# Altium schematic sheet MCU Power Clock Reset.SchDoc
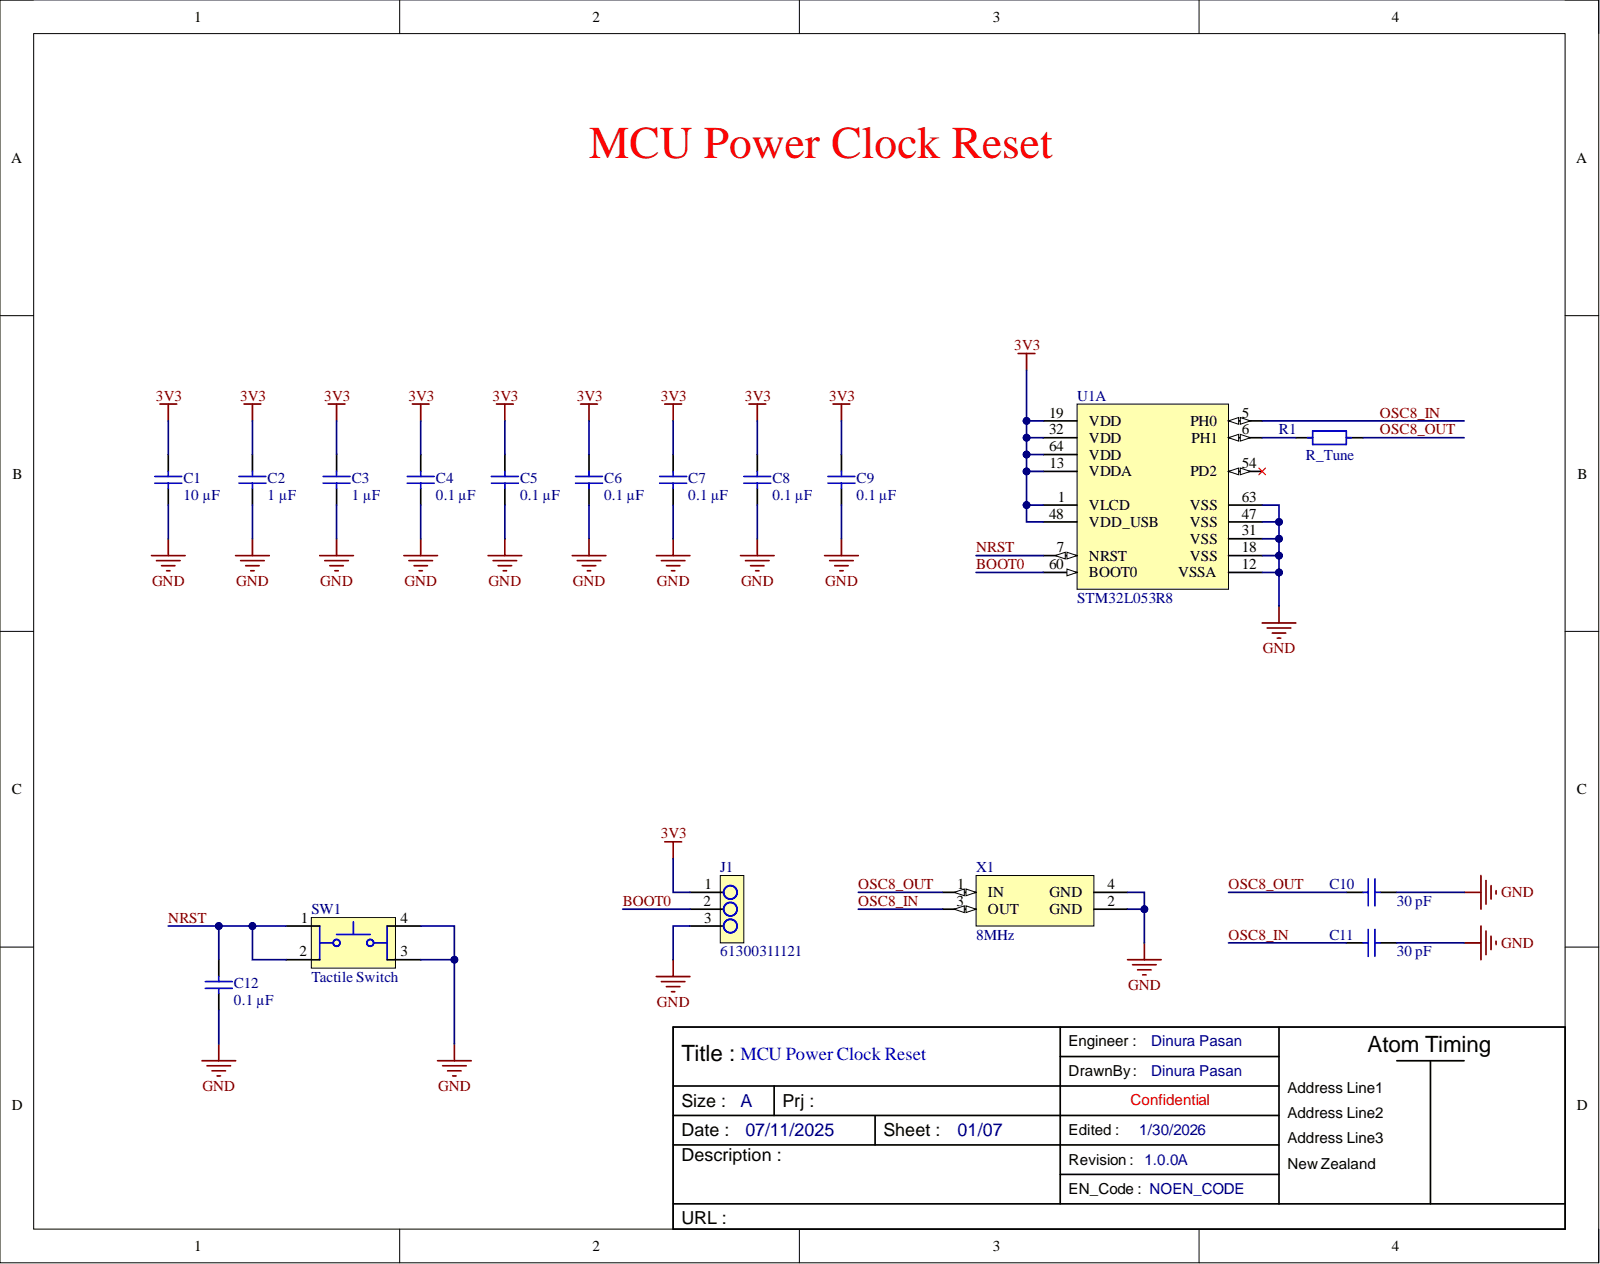
[diagram p0: MCU Power Clock Reset.SchDoc]

## Parts
C1  10 µF  [TDK_CGA1206N]
C2  1 µF  [TDK_CGA0805N]
C3  1 µF  [TDK_CGA0805N]
C4  0.1 µF  [TDK_CGA0805N]
C5  0.1 µF  [TDK_CGA0805N]
C6  0.1 µF  [TDK_CGA0805N]
C7  0.1 µF  [TDK_CGA0805N]
C8  0.1 µF  [TDK_CGA0805N]
C9  0.1 µF  [TDK_CGA0805N]
C10  30 pF  [YAGEO_CCNP00402N]
C11  30 pF  [YAGEO_CCNP00402N]
C12  0.1 µF  [TDK_CGA0805N]
J1  61300311121  [61300311121]
R1  R_Tune  [Panasonic_ERA6AN]  (no BOM)
SW1  Tactile Switch  [TL3313AF160QG]
U1  STM32L053R8  [ST-LQFP64]  (part A)
X1  8MHz  [ECS-80-10-33-CKM-TR3]

## Nets
3V3: C1.2 C2.2 C3.2 C4.2 C5.2 C6.2 C7.2 C8.2 C9.2 J1.1 U1.1(VLCD) U1.13(VDDA) U1.19(VDD) U1.32(VDD) U1.48(VDD_USB) U1.64(VDD)
GND: C1.1 C2.1 C3.1 C4.1 C5.1 C6.1 C7.1 C8.1 C9.1 C10.2 C11.2 C12.2 J1.3 SW1.3 SW1.4 U1.12(VSSA) U1.18(VSS) U1.31(VSS) U1.47(VSS) U1.63(VSS) X1.2(GND) X1.4(GND)
BOOT0: J1.2 U1.60(BOOT0)
NRST: C12.1 SW1.1 SW1.2 U1.7(NRST)
OSC8_IN: C11.1 U1.5(PH0) X1.3(OUT)
OSC8_OUT: C10.1 R1.1 X1.1(IN)
NetR1_2: R1.2 U1.6(PH1)

Not connected: U1 54(PD2)

## Library data of the parts
C1 = CGA5L1X7R1C106M160AC: 10 µF ±20% 16V X7R -55°C ~ 125°C 1206 (3216 Metric); Manufacturer: TDK Corporation; Database Table Name: Sheet1$; Eco Plan: RoHS & Green; Initial Voltage: 0V; TEMP: 25; Value: 10u; Capacitance: 10 µF; Category: Ceramic Capacitor (MLCC); Component Kind: Standard; Datasheet: https://product.tdk.com/system/files/dam/doc/product/capacitor/ceramic/mlcc/catalog/mlcc_automotive_general_en.pdf; Library Name: MLCC.DbLib; Manufacturer Part Number: CGA5L1X7R1C106M160AC; Operating Temperature: -55°C ~ 125°C; Package / Case: 1206 (3216 Metric); Ratings: AEC-Q200; Series: CGA; Simulation: C_Capacitor_Default; Size / Dimension: 0.126" L x 0.063" W (3.20mm x 1.60mm); Supplier 1: Digi-Key; Supplier Part Number 1: 445-12903-2-ND; Temperature Coefficient: X7R; Thickness (Max): 0.075" (1.90mm); Tolerance: ±20%; Voltage - Rated: 16V
C2 C3 = CGA4J3X7R1H105K125AB: 1 µF ±10% 50V X7R -55°C ~ 125°C 0805 (2012 Metric); Manufacturer: TDK Corporation; Database Table Name: Sheet1$; Eco Plan: RoHS & Green; Initial Voltage: 0V; TEMP: 25; Value: 1u; Capacitance: 1 µF; Category: Ceramic Capacitor (MLCC); Component Kind: Standard; Datasheet: https://product.tdk.com/system/files/dam/doc/product/capacitor/ceramic/mlcc/catalog/mlcc_automotive_general_en.pdf; Library Name: MLCC.DbLib; Manufacturer Part Number: CGA4J3X7R1H105K125AB; Operating Temperature: -55°C ~ 125°C; Package / Case: 0805 (2012 Metric); Ratings: AEC-Q200; Series: CGA; Simulation: C_Capacitor_Default; Size / Dimension: 0.079" L x 0.049" W (2.00mm x 1.25mm); Supplier 1: Digi-Key; Supplier Part Number 1: 445-6961-2-ND; Temperature Coefficient: X7R; Thickness (Max): 0.059" (1.50mm); Tolerance: ±10%; Voltage - Rated: 50V
C4-C9 C12 = CGA4J2X7R2A104K125AE: 0.1 µF ±10% 100V X7R -55°C ~ 125°C 0805 (2012 Metric); Manufacturer: TDK Corporation; Database Table Name: Sheet1$; Eco Plan: RoHS & Green; Initial Voltage: 0V; TEMP: 25; Value: 0.1u; Capacitance: 0.1 µF; Category: Ceramic Capacitor (MLCC); Component Kind: Standard; Datasheet: https://product.tdk.com/system/files/dam/doc/product/capacitor/ceramic/mlcc/catalog/mlcc_automotive_general_en.pdf; Library Name: MLCC.DbLib; Manufacturer Part Number: CGA4J2X7R2A104K125AE; Operating Temperature: -55°C ~ 125°C; Package / Case: 0805 (2012 Metric); Ratings: AEC-Q200; Series: CGA; Simulation: C_Capacitor_Default; Size / Dimension: 0.079" L x 0.049" W (2.00mm x 1.25mm); Supplier 1: Digi-Key; Supplier Part Number 1: 445-15961-2-ND; Temperature Coefficient: X7R; Thickness (Max): 0.059" (1.50mm); Tolerance: ±10%; Voltage - Rated: 100V
C10 C11 = CC0402GRNPO9BN300: 30 pF ±2% 50V C0G, NP0 -55°C ~ 125°C 0402 (1005 Metric); Manufacturer: YAGEO; Database Table Name: Sheet1$; Eco Plan: RoHS & Green; Initial Voltage: 0V; TEMP: 25; Value: 30p; Capacitance: 30 pF; Category: Ceramic Capacitor (MLCC); Component Kind: Standard; Datasheet: https://www.yageo.com/upload/media/product/products/datasheet/mlcc/UPY-GP_NP0_16V-to-50V_18.pdf; Library Name: MLCC.DbLib; Manufacturer Part Number: CC0402GRNPO9BN300; Operating Temperature: -55°C ~ 125°C; Package / Case: 0402 (1005 Metric); Ratings: -; Series: CC NP0; Simulation: C_Capacitor_Default; Size / Dimension: 0.039" L x 0.020" W (1.00mm x 0.50mm); Supplier 1: Digi-Key; Supplier Part Number 1: 311-3740-2-ND; Temperature Coefficient: C0G, NP0; Thickness (Max): 0.022" (0.55mm); Tolerance: ±2%; Voltage - Rated: 50V
J1 = 61300311121: Connector Header Through Hole 3 position 0.100" (2.54mm); Manufacturer 1: Wurth Elektronik; Category 1: Headers and Wire Housings; Connector Type: Male Pin; Contact Resistance: 20mΩ; Current Rating: 3A; Description 1: THT Vertical Pin Header WR-PHD, Pitch 2.54 mm, Single Row, 3 pins; Gender: Male; Number of Contacts: 3; Operating Supply Voltage: 250V; Packaging 1: Bulk; Color: Black; Component Kind: Standard; ComponentLink1Description: https://datasheet.octopart.com:443/61300311121-Wurth-Elektronik-datasheet-168684096.pdf?src-supplier=Upverter; ComponentLink1URL: https://datasheet.octopart.com:443/61300311121-Wurth-Elektronik-datasheet-168684096.pdf?src-supplier=Upverter; ComponentLink2Description: https://datasheet.octopart.com:443/61300311121-W%C3%BCrth-Elektronik-datasheet-44382959.pdf?src-supplier=Future+Electronics; ComponentLink2URL: https://datasheet.octopart.com:443/61300311121-W%C3%BCrth-Elektronik-datasheet-44382959.pdf?src-supplier=Future+Electronics; ComponentLink3Description: https://datasheet.octopart.com:443/61300311121-W%C3%BCrth-Elektronik-datasheet-149524047.pdf?src-supplier=Farnell; ComponentLink3URL: https://datasheet.octopart.com:443/61300311121-W%C3%BCrth-Elektronik-datasheet-149524047.pdf?src-supplier=Farnell; ComponentLink4Description: https://datasheet.octopart.com:443/61300311121-W%C3%BCrth-Elektronik-datasheet-21154836.pdf?src-supplier=element14+APAC; ComponentLink4URL: https://datasheet.octopart.com:443/61300311121-W%C3%BCrth-Elektronik-datasheet-21154836.pdf?src-supplier=element14+APAC; Contact Material: Gold; Contact Mating Length: 5.9944mm; Contact Plating: Gold; Height: 8.94mm; Lead Free 1: Lead Free; Lead Pitch: 2.54mm; Library Name: Components.IntLib; Manufacturer Part Number 1: 61300311121; Max Operating Temperature 1: 105°C; Min Operating Temperature 1: -40°C; Mount 1: Through Hole; Number of Pins 1: 3; Number of Positions: 3; Number of Rows: 1; Orientation: Vertical; Pitch: 2.54mm; RoHS 1: Compliant; Supplier 1: DigiKey; Supplier 2: Mouser; Supplier 3: Newark; Supplier 4: Farnell; Supplier Part Number 1: 732-5316-ND; Supplier Part Number 2: 710-61300311121; Supplier Part Number 3: 61300311121; Supplier Part Number 4: 2356154; Termination: Solder; Voltage Rating: 250V
R1 = ERA-6ARW102V: 1 kΩ ±0.05% 0.125W, 1/8W Thin Film 0805 (2012 Metric) 100V ±10ppm/°C -55°C ~ 155°C; Manufacturer: Panasonic Electronic Components; Database Table Name: Sheet1$; Eco Plan: RoHS & Green; Maximum Overload Voltage: 200V; Rated Voltage: √(PxR); Resistance: 1 kΩ; TC: 10; TC1: 0; TEMP: 25; Value: 1kΩ; Category: SMD Thin Film Resistor; Composition: Thin Film; Datasheet: https://industrial.panasonic.com/cdbs/www-data/pdf/RDM0000/AOA0000C307.pdf; Height - Seated (Max): 0.024" (0.60mm); Library Name: SMD_Thin_Film_Resistors.DbLib; Manufacturer Part Number: ERA-6ARW102V; Maximum Working Voltage: 100V; Operating Temperature: -55°C ~ 155°C; Package / Case: 0805 (2012 Metric); Power (Watts): 0.125W, 1/8W; Ratings: AEC-Q200; Series: ERA; Simulation: R_Resistor_Default; Size / Dimension: 0.079" L x 0.049" W (2.00mm x 1.25mm); Supplier 1: Digi-Key; Supplier Part Number 1: P1.0KBSTR-ND; TC2: 0; Temperature Coefficient: ±10ppm/°C; Tolerance: ±0.05%
SW1 = TL3313AF160QG: Tactile Switch SPST-NO Top Actuated Surface Mount; Manufacturer: E-Switch; Actuator Length: 0.3mm; Actuator Type: Standard; Contact Resistance: 50mR; Current Rating: 50mA; Illumination Type: Non-Illuminated; Max Voltage Rating (DC): 12V; Mechanical Life: 100000Cycles; Operating Force: 160gf; Packaging 1: Tape and Reel; Switch Function: Off-Mom; Switch Travel: 0.25mm; Throw Configuration: SPST; Category: Tactile Switches; Component Kind: Standard; ComponentLink1Description: Datasheet; ComponentLink1URL: https://sten-eswitch-13110800-production.s3.amazonaws.com/system/asset/product_line/data_sheet/161/TL3313.pdf; Library Name: Common_library.IntLib; Manufacturer Part Number: TL3313AF160QG; Max Operating Temperature 1: 70°C; Min Operating Temperature 1: -20°C; Mount: Surface Mount; Orientation: Straight; Package Quantity: 4000; RoHS: No; RoHS Compliant 1: No; Series: TL3313; Supplier 1: Digi-Key; Supplier Part Number 1: EG6064CT-ND; Termination: Gull Wing; Voltage Rating (DC): 12V
U1 = STM32L053R8: Ultra-low-power Arm Cortex-M0+ MCU with 64 Kbytes of Flash memory, 32 MHz CPU, USB, LCD; Manufacturer 1: STMicroelectronics; Manufacturer Part Number 1: STM32L053R8T6D; ADC Channels (Resolution): 16-Ch 12-Bit; Case/Package 1: LQFP; Category 1: Microcontrollers; DAC Channels (Resolution): 1-Ch 12-Bit; Description 1: Ultra-low-power ARM Cortex-M0+ MCU with 64 Kbytes Flash, 32 MHz CPU, USB, LCD; EEPROM Memory Size: 2kB; Flash Memory Size: 64kB; Frequency: 32MHz; Interface 1: I2S, UART, USB; Max Frequency: 25MHz; Max Supply Voltage 1: 3.6V; Min Supply Voltage 1: 1.65V; Nominal Supply Current: 290nA; Number of D/A Converters: 1; Number of I2C Channels: 2; Number of I/Os: 51; Number of Pins 1: 64; Number of Timers/Counters: 4; Operating Supply Voltage: 3V; Oscillator Type: Internal; Peripherals: LCD, POR; RAM Size: 8kB; China RoHS: Compliant; Component Kind: Standard; ComponentLink1Description: https://datasheet.octopart.com:443/STM32L053R8T6D-STMicroelectronics-datasheet-109682748.pdf?src-supplier=IHS; ComponentLink1URL: https://datasheet.octopart.com:443/STM32L053R8T6D-STMicroelectronics-datasheet-109682748.pdf?src-supplier=IHS; ComponentLink2Description: https://datasheet.octopart.com:443/STM32L053R8T6D-STMicroelectronics-datasheet-27724236.pdf?src-supplier=Upverter; ComponentLink2URL: https://datasheet.octopart.com:443/STM32L053R8T6D-STMicroelectronics-datasheet-27724236.pdf?src-supplier=Upverter; ComponentLink3Description: https://datasheet.octopart.com:443/STM32L053R8T6D-STMicroelectronics-datasheet-62336778.pdf?src-supplier=STMicroelectronics; ComponentLink3URL: https://datasheet.octopart.com:443/STM32L053R8T6D-STMicroelectronics-datasheet-62336778.pdf?src-supplier=STMicroelectronics; ComponentLink4Description: https://datasheet.octopart.com:443/STM32L053R8T6D-STMicroelectronics-datasheet-22146913.pdf?src-supplier=STMicroelectronics; ComponentLink4URL: https://datasheet.octopart.com:443/STM32L053R8T6D-STMicroelectronics-datasheet-22146913.pdf?src-supplier=STMicroelectronics; ComponentLink5Description: https://datasheet.octopart.com:443/STM32L053R8T6D-STMicroelectronics-datasheet-38781224.pdf?src-supplier=element14+APAC; ComponentLink5URL: https://datasheet.octopart.com:443/STM32L053R8T6D-STMicroelectronics-datasheet-38781224.pdf?src-supplier=element14+APAC; ComponentLink6Description: https://datasheet.octopart.com:443/STM32L053R8T6D-STMicroelectronics-datasheet-48218917.pdf?src-supplier=element14+APAC; ComponentLink6URL: https://datasheet.octopart.com:443/STM32L053R8T6D-STMicroelectronics-datasheet-48218917.pdf?src-supplier=element14+APAC; ComponentLink7Description: https://datasheet.octopart.com:443/STM32L053R8T6D-STMicroelectronics-datasheet-159009258.pdf?src-supplier=SHENGYU+ELECTRONICS; ComponentLink7URL: https://datasheet.octopart.com:443/STM32L053R8T6D-STMicroelectronics-datasheet-159009258.pdf?src-supplier=SHENGYU+ELECTRONICS; Core Architecture: ARM Cortex-M0+; Height - Seated (Max): 1.6mm; Ibis Model: stm32l0_51_52_53_62_63_lqfp64; Length: 10mm; Library Name: ICs.IntLib; Lifecycle Status: Production; Manufacturer Part Number 2: STM32L053R8T6TR; Manufacturer Part Number 3: STM32L053R8T3; Manufacturer Part Number 4: STM32L053R8T6; Manufacturer Part Number 5: STM32L053R8T7; Max Operating Temperature 1: 85°C; Memory Size: 64kB; Memory Type: FLASH; Min Operating Temperature 1: -40°C; Number of SPI Channels: 2; Number of Terminals: 64; Number of USART Channels: 2; REACH SVHC: Yes; RoHS 1: Compliant; Supplier 1: Arrow Electronics; Supplier 2: DigiKey; Supplier 3: Avnet; Supplier 4: Arrow Electronics; Supplier 5: Avnet; Supplier 6: DigiKey; Supplier 7: DigiKey; Supplier 8: DigiKey; Supplier 9: Mouser; Supplier 10: Future Electronics; Supplier 11: Newark; Supplier 12: Farnell; Supplier 13: Farnell; Supplier 14: Mouser; Supplier 15: DigiKey; Supplier 16: Avnet; Supplier 17: Arrow Electronics; Supplier 18: Farnell; Supplier 19: Arrow Electronics; Supplier 20: Avnet; Supplier 21: DigiKey; Supplier 22: Mouser; Supplier 23: Future Electronics; Supplier 24: Newark; Supplier 25: DigiKey; Supplier 26: Mouser; Supplier 27: Arrow Electronics; Supplier 28: Avnet; Supplier 29: Farnell; Supplier Part Number 1: STM32L053R8T6D; Supplier Part Number 2: STM32L053R8T6D-ND; Supplier Part Number 3: STM32L053R8T6D; Supplier Part Number 4: STM32L053R8T6TR; Supplier Part Number 5: STM32L053R8T6TR; Supplier Part Number 6: 497-19667-1-ND; Supplier Part Number 7: 497-19667-6-ND; Supplier Part Number 8: 497-19667-2-ND; Supplier Part Number 9: 511-STM32L053R8T6TR; Supplier Part Number 10: 5087979; Supplier Part Number 11: 54AH7029; Supplier Part Number 12: 3365459; Supplier Part Number 13: 3365459RL; Supplier Part Number 14: 511-STM32L053R8T3; Supplier Part Number 15: 497-18575-ND; Supplier Part Number 16: STM32L053R8T3; Supplier Part Number 17: STM32L053R8T3; Supplier Part Number 18: 2490881; Supplier Part Number 19: STM32L053R8T6; Supplier Part Number 20: STM32L053R8T6; Supplier Part Number 21: 497-14654-ND; Supplier Part Number 22: 511-STM32L053R8T6; Supplier Part Number 23: 6170600; Supplier Part Number 24: 28AC3380; Supplier Part Number 25: 497-17875-ND; Supplier Part Number 26: 511-STM32L053R8T7; Supplier Part Number 27: STM32L053R8T7; Supplier Part Number 28: STM32L053R8T7; Supplier Part Number 29: 2490883; Terminal Pitch: 500µm; Width: 10mm
X1 = ECS-80-10-33-CKM-TR3: 8 MHz ±10ppm Crystal 10pF 400 Ohms 4-SMD, No Lead; Manufacturer 1: ECS International; Category 1: Crystals; Description 1: Crystals 8.000 MHz, CL 10, TOL +/-10 ppm , STAB +/-10 ppm, -20 +70 C, ESR 400O, 3.2X2.5 mm; Component Kind: Standard; ComponentLink1Description: https://datasheet.octopart.com:443/ECS-80-10-33-CKM-TR3-ECS-International-datasheet-179230830.pdf?src-supplier=Electro+Sonic; ComponentLink1URL: https://datasheet.octopart.com:443/ECS-80-10-33-CKM-TR3-ECS-International-datasheet-179230830.pdf?src-supplier=Electro+Sonic; ComponentLink2Description: https://datasheet.octopart.com:443/ECS-80-10-33-CKM-TR3-ECS-International-datasheet-140089731.pdf?src-supplier=Master+Electronics; ComponentLink2URL: https://datasheet.octopart.com:443/ECS-80-10-33-CKM-TR3-ECS-International-datasheet-140089731.pdf?src-supplier=Master+Electronics; Library Name: Components.IntLib; Manufacturer Part Number 1: ECS-80-10-33-CKM-TR3; Supplier 1: DigiKey; Supplier Part Number 1: 50-ECS-80-10-33-CKM-TR3CT-ND
